# Altium schematic sheet MCU.SchDoc
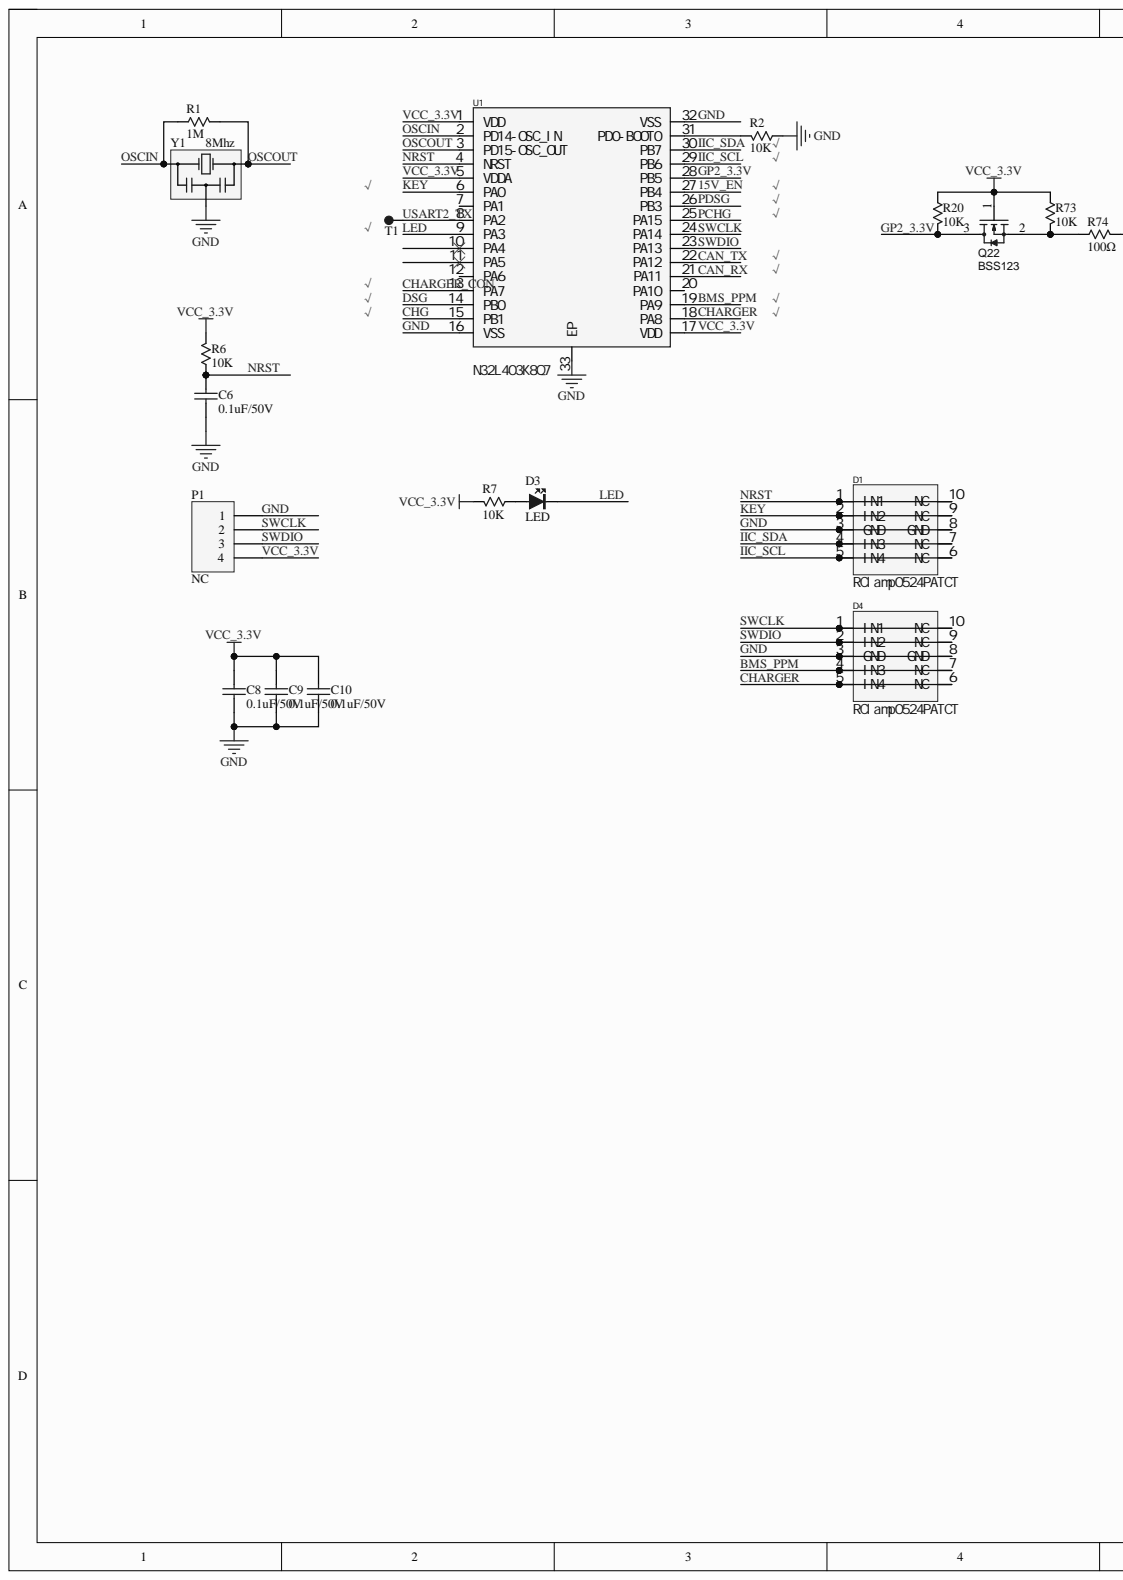
[diagram p0: MCU.SchDoc, left]
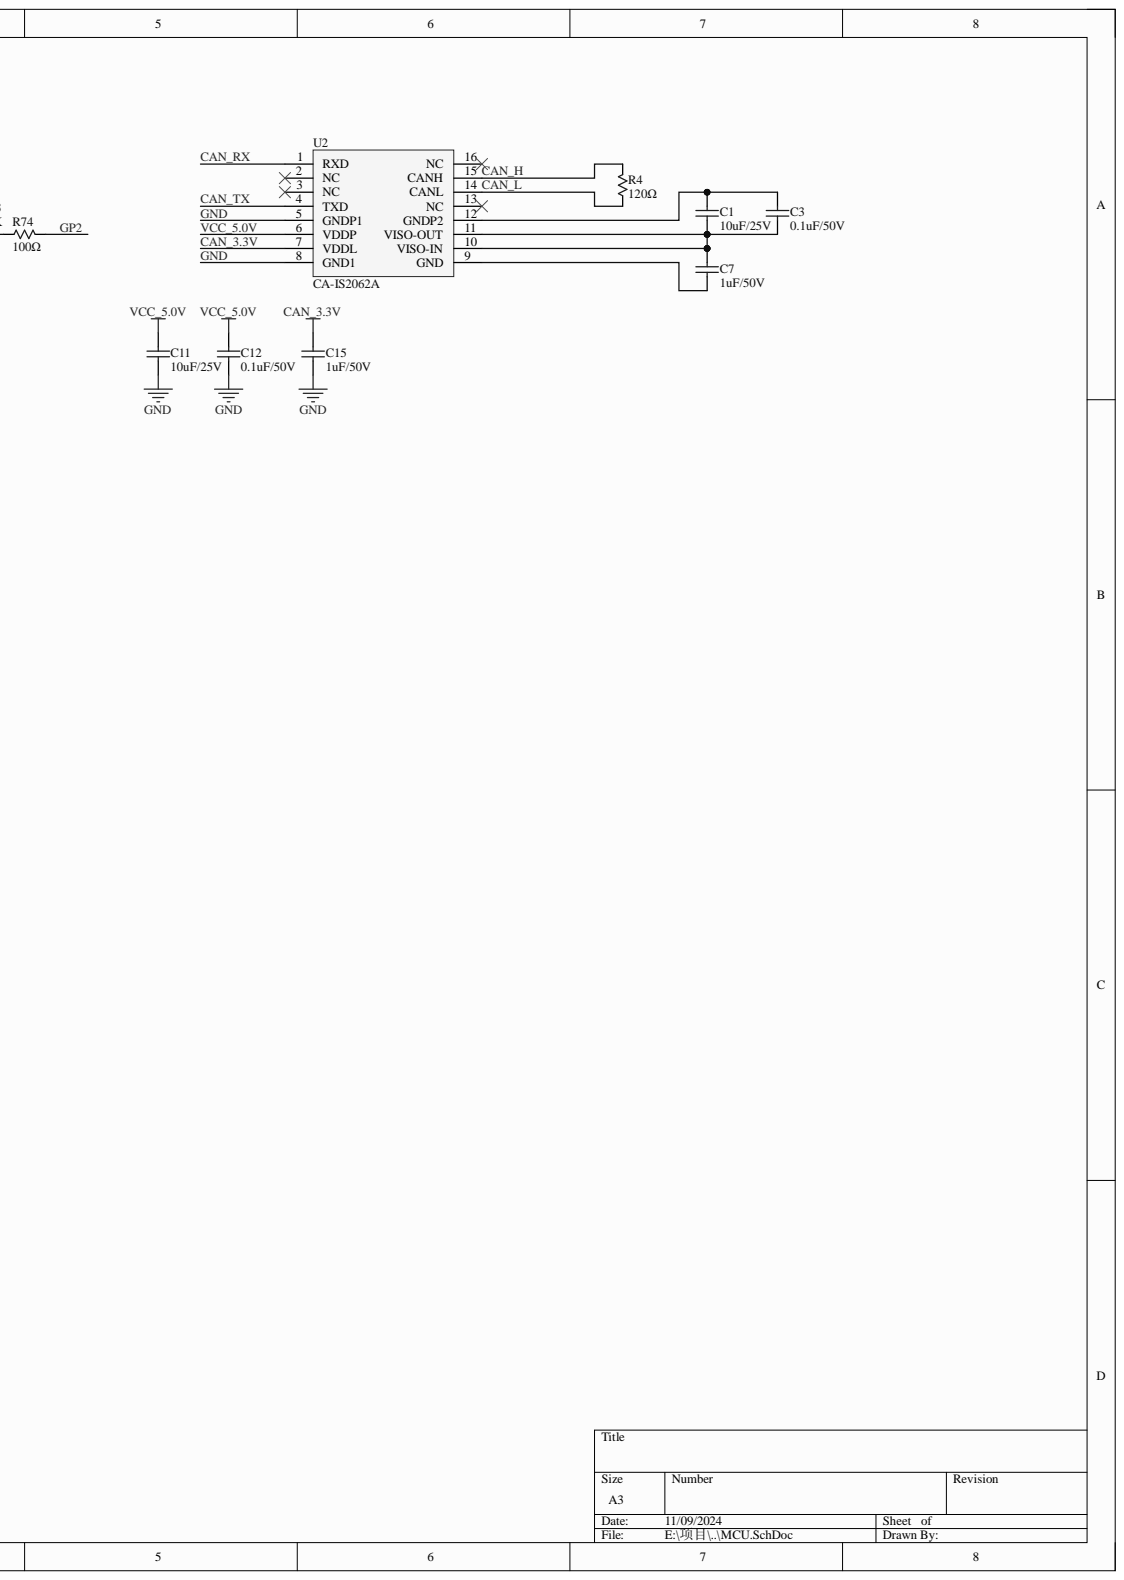
[diagram p1: MCU.SchDoc, right]

## Parts
C1  10uF/25V  [0603_C]
C3  0.1uF/50V  [0603_C]
C6  0.1uF/50V  [0603_C]
C7  1uF/50V  [0603_C]
C8  0.1uF/50V  [0603_C]
C9  0.1uF/50V  [0603_C]
C10  0.1uF/50V  [0603_C]
C11  10uF/25V  [0603_C]
C12  0.1uF/50V  [0603_C]
C15  1uF/50V  [0603_C]
D1  RClamp0524PATCT  [SLP2510-8]
D3  LED  [LED-0603G]
D4  RClamp0524PATCT  [SLP2510-8]
P1  NC  [HDR1X4]
Q22  BSS123  [SOT-23-3]
R1  1M  [0603_R]
R2  10K  [0603_R]
R4  120Ω  [0805_R]
R6  10K  [0603_R]
R7  10K  [0603_R]
R20  10K  [0603_R]
R73  10K  [0603_R]
R74  100Ω  [0603_R]
T1  T  [T_1.5mm]
U1  N32L403K8Q7  [QFN-32-EP(4x4)]
U2  CA-IS2062A  [LGA16]
Y1  8Mhz  [SMD3213_3P]

## Nets
15V_EN: U1.27(PB4)
BMS_PPM: D4.4(IN3) D4.7(NC) U1.19(PA9)
CAN_3.3V: C15.1 U2.7(VDDL)
CAN_H: R4.1 U2.15(CANH)
CAN_L: R4.2 U2.14(CANL)
CAN_RX: U1.21(PA11) U2.1(RXD)
CAN_TX: U1.22(PA12) U2.4(TXD)
CHARGER: D4.5(IN4) D4.6(NC) U1.18(PA8)
CHARGER_CON: U1.13(PA7)
CHG: U1.15(PB1)
DSG: U1.14(PB0)
GND: C6.1 C8.2 C9.2 C10.2 C11.1 C12.2 C15.2 D1.3(GND) D1.8(GND) D4.3(GND) D4.8(GND) P1.1 R2.2 U1.16(VSS) U1.32(VSS) U1.33(EP) U2.5(GNDP1) U2.8(GND1) Y1.2
GP2: R74.1
GP2_3.3V: Q22.3 R20.1 U1.28(PB5)
IIC_SCL: D1.5(IN4) D1.6(NC) U1.29(PB6)
IIC_SDA: D1.4(IN3) D1.7(NC) U1.30(PB7)
KEY: D1.2(IN2) D1.9(NC) U1.6(PA0)
LED: D3.K U1.9(PA3)
NRST: C6.2 D1.1(IN1) D1.10(NC) R6.2 U1.4(NRST)
OSCIN: R1.2 U1.2(PD14-OSC_IN) Y1.1
OSCOUT: R1.1 U1.3(PD15-OSC_OUT) Y1.3
PCHG: U1.25(PA15)
PDSG: U1.26(PB3)
SWCLK: D4.1(IN1) D4.10(NC) P1.2 U1.24(PA14)
SWDIO: D4.2(IN2) D4.9(NC) P1.3 U1.23(PA13)
USART2_TX: T1.1 U1.8(PA2)
VCC_3.3V: C8.1 C9.1 C10.1 P1.4 Q22.1 R6.1 R7.1 R20.2 R73.2 U1.1(VDD) U1.5(VDDA) U1.17(VDD)
VCC_5.0V: C11.2 C12.1 U2.6(VDDP)
NetC1_1: C1.1 C3.2 C7.1 U2.10(VISO-IN) U2.11(VISO-OUT)
NetC1_2: C1.2 C3.1 U2.12(GNDP2)
NetC7_2: C7.2 U2.9(GND)
NetD3_A: D3.A R7.2
NetQ22_2: Q22.2 R73.1 R74.2
NetR2_1: R2.1 U1.31(PD0-BOOT0)

Not connected: U1 7(PA1) 10(PA4) 11(PA5) 12(PA6) 20(PA10); U2 2(NC) 3(NC) 13(NC) 16(NC)

## Library data of the parts
C1 C3 C6-C12 C15 = Cap: Capacitor; Component Kind: Standard; LatestRevisionDate: 17-Jul-2002; LatestRevisionNote: Re-released for DXP Platform.; PackageReference: RAD-0.3; Published: 8-Jun-2000; Signal Integrity: Cap; Simulation: CAP; Library Name: UP-ROBOT Devices.SchLib; Publisher: Altium Limited
  C1 C11: Value: 10uF/25V
  C3 C6 C8-C10 C12: Value: 0.1uF/50V
  C7 C15: Value: 1uF/50V
D1 D4 = RClamp0524PATCT: Manufacturer: SEMTECH; Add into BOM: yes; Breakdown Voltage (VBR): 6V; Clamping Voltage@Ipp: 15V; Device: RClamp0524PATCT_C40960; Junction Capacitance(Cj)@1MHz: 0.8pF@1MHz; Number of Lines: 4; Peak Pulse Power(Ppp)@8/20us: 150W; Reverse Stand-Off Voltage (Vrwm): 5V; Component Kind: Standard; Convert to PCB: yes; Datasheet: https://atta.szlcsc.com/upload/public/pdf/source/20150124/1457707260739.pdf; JLCPCB Part Class: Extended Part; LCSC Part Name: RClamp0524PATCT; Library Name: DVC1124_20S.SchLib; Manufacturer Part: RClamp0524PATCT; Name: RClamp0524PATCT; Operating Temperature: -55℃~+125℃@(Tj); Supplier: LCSC; Supplier Footprint: SLP2510-8; Supplier Part: C40960; Symbol: RClamp0524PATCT
P1 = Header 4: Header, 4-Pin; Component Kind: Standard; LatestRevisionDate: 17-Jul-2002; LatestRevisionNote: Re-released for DXP Platform.; Library Name: Miscellaneous Connectors.IntLib; Signal Integrity: Connector; Publisher: Altium Limited
Q22 = BSS131: BOM_Manufacturer: Ncepower; BOM_Manufacturer Part: NCE0102; Contributor: LCSC; BOM_JLCPCB Part Class: Extended Part; BOM_Supplier: LCSC; BOM_Supplier Part: C161843; Component Kind: Standard; Library Name: DVC1124_20S.SchLib; spicePre: Q; spiceSymbolName: NCE0102
R1 R2 R4 R6 R7 R20 R73 R74 = Res: Resistor; Component Kind: Standard; LatestRevisionDate: 17-Jul-2002; LatestRevisionNote: Re-released for DXP Platform.; PackageReference: AXIAL-0.3; Published: 8-Jun-2000; Signal Integrity: Res; Simulation: RESISTOR; Library Name: UP-ROBOT Devices.SchLib; Publisher: Altium Limited
  R1: Value: 1M
  R2 R6 R7 R20 R73: Value: 10K
  R4: Value: 120Ω
  R74: Value: 100Ω
T1 = TestPad: Component Kind: Standard; Library Name: ChassisCon.SCHLIB
U1 = N32L403K8Q7: Manufacturer: Nations(国民技术); Add into BOM: yes; Device: N32G430K8Q7; Component Kind: Standard; Convert to PCB: yes; Datasheet: https://atta.szlcsc.com/upload/public/pdf/source/20220608/411F2C1C49F3955F5F0689A973473AF7.pdf; JLCPCB Part Class: Extended Part; LCSC Part Name: N32G430K8Q7; Library Name: BMS_20S_MCU_MOS.SchLib; Manufacturer Part: N32G430K8Q7; Name: N32G430K8Q7; Supplier: LCSC; Supplier Footprint: QFN32; Supplier Part: C3032172; Symbol: N32G430K8Q7
Y1 = XTAL-CSTCE-V: Resonator Oscillator; Component Kind: Standard; Published: 8-Jun-2000; Revision: July-2002: Re-released for DXP Platform.; Simulation: XTAL; Library Name: UP-ROBOT Devices.SchLib; Publisher: Altium Limited
D3 = LED: Component Kind: Standard; Library Name: UP-ROBOT Devices.SchLib
U2 = CA-IS2062A: Component Kind: Standard; Library Name: DCDC_CAN.SchLib
